# Altium schematic sheet 07)RADEX.SchDoc(07)RADEX)
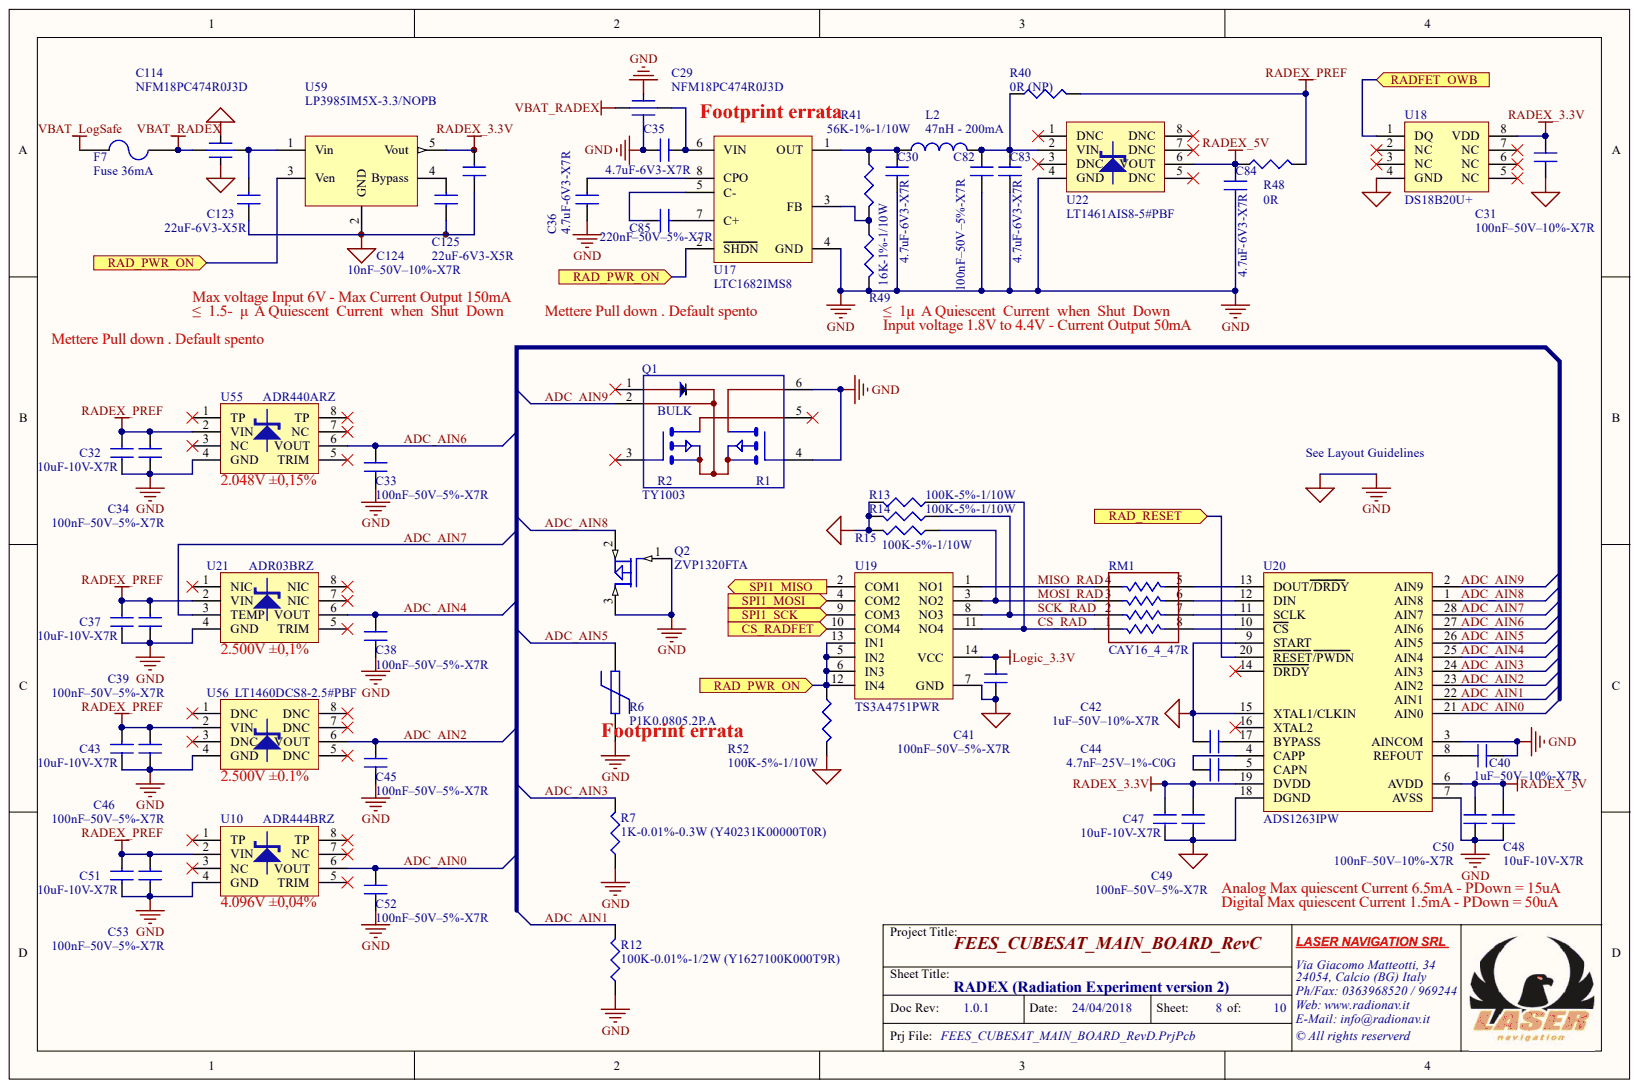
[diagram p0: 07)RADEX.SchDoc]

## Parts
C29  NFM18PC474R0J3D  [0603_EMI_NFM]
C30  4.7uF-6V3-X7R  [0603_CAP]
C31  100nF–50V–10%-X7R  [0603_CAP]
C32  10uF-10V-X7R  [0805_CAP]
C33  100nF–50V–5%-X7R  [0603_CAP]
C34  100nF–50V–5%-X7R  [0603_CAP]
C35  4.7uF-6V3-X7R  [0603_CAP]
C36  4.7uF-6V3-X7R  [0603_CAP]
C37  10uF-10V-X7R  [0805_CAP]
C38  100nF–50V–5%-X7R  [0603_CAP]
C39  100nF–50V–5%-X7R  [0603_CAP]
C40  1uF–50V–10%-X7R  [0805_CAP]
C41  100nF–50V–5%-X7R  [0603_CAP]
C42  1uF–50V–10%-X7R  [0805_CAP]
C43  10uF-10V-X7R  [0805_CAP]
C44  4.7nF–25V–1%-C0G  [0603_CAP]
C45  100nF–50V–5%-X7R  [0603_CAP]
C46  100nF–50V–5%-X7R  [0603_CAP]
C47  10uF-10V-X7R  [0805_CAP]
C48  10uF-10V-X7R  [0805_CAP]
C49  100nF–50V–5%-X7R  [0603_CAP]
C50  100nF–50V–10%-X7R  [0603_CAP]
C51  10uF-10V-X7R  [0805_CAP]
C52  100nF–50V–5%-X7R  [0603_CAP]
C53  100nF–50V–5%-X7R  [0603_CAP]
C82  100nF–50V–5%-X7R  [0603_CAP]
C83  4.7uF-6V3-X7R  [0603_CAP]
C84  4.7uF-6V3-X7R  [0603_CAP]
C85  220nF–50V–5%-X7R  [0603_CAP]
C114  NFM18PC474R0J3D  [0603_EMI_NFM]
C123  22uF-6V3-X5R  [0805_CAP]
C124  10nF–50V–10%-X7R  [0603_CAP]
C125  22uF-6V3-X5R  [0805_CAP]
F7  Fuse 36mA  [0603_FUSE]
L2  47nH - 200mA  [0603_IND]
Q1  TY1003  [SOT23-6]
Q2  ZVP1320FTA  [SOT23]
R6  P1K0.0805.2P.A  [1206_RES]
R7  1K-0.01%-0.3W (Y40231K00000T0R)  [1206_RES]
R12  100K-0.01%-1/2W (Y1627100K000T9R)  [2010_RES]
R13  100K-5%-1/10W  [0603_RES]
R14  100K-5%-1/10W  [0603_RES]
R15  100K-5%-1/10W  [0603_RES]
R40  0R (NP)  [0603_RES]
R41  56K-1%-1/10W  [0603_RES]
R48  0R  [0603_RES]
R49  16K-1%-1/10W  [0603_RES]
R52  100K-5%-1/10W  [0603_RES]
RM1  CAY16_4_47R  [RETE_CAY16_4]
U10  ADR444BRZ  [SO8]
U17  LTC1682IMS8  [TSSOP8]
U18  DS18B20U+  [MSOP8]
U19  TS3A4751PWR  [TSSOP14]
U20  ADS1263IPW  [TSSOP28]
U21  ADR03BRZ  [SO8]
U22  LT1461AIS8-5#PBF  [SO8]
U55  ADR440ARZ  [SO8]
U56  LT1460DCS8-2.5#PBF  [SO8]
U59  LP3985IM5X-3.3/NOPB  [SOT23-5]

## Ports
CS_RADFET, RAD_PWR_ON, RAD_RESET, RADFET_OWB, SPI1_MISO, SPI1_MOSI, SPI1_SCK

## Nets
GND: C29.3 C29.4 C30.2 C31.2 C32.1 C33.2 C34.2 C35.1 C36.2 C37.1 C38.2 C39.2 C40.2 C41.2 C42.2 C43.1 C45.2 C46.2 C47.1 C48.1 C49.2 C50.2 C51.1 C52.2 C53.2 C82.2 C83.2 C84.2 C114.3 C114.4 C123.2 C124.2 C125.2 Q1.4 Q1.6 Q2.1 Q2.3 R6.1 R7.1 R12.1 R13.2 R14.2 R15.2 R49.2 R52.2 U10.4(GND) U17.4(GND) U18.4(GND) U19.7(GND) U20.3(AINCOM) U20.7(AVSS) U20.9(START) U20.15(XTAL1/CLKIN) U20.18(DGND) U21.4(GND) U22.4(GND) U55.4(GND) U56.4(GND) U59.2(GND)
LOGIC_3.3V: C41.1 U19.14(VCC)
RADEX_3.3V: C31.1 C47.2 C49.1 C125.1 U18.8(VDD) U20.19(DVDD) U59.5(Vout)
RADEX_5V: C48.2 C50.1 C84.1 R48.1 U20.6(AVDD) U22.6(VOUT)
RADEX_PREF: C32.2 C34.1 C37.2 C39.1 C43.2 C46.1 C51.2 C53.1 R40.2 R48.2 U10.2(VIN) U21.2(VIN) U55.2(VIN) U56.2(VIN)
VBAT_LOGSAFE: F7.1
VBAT_RADEX: C29.1 C114.1 F7.2
ADC_AIN0: C52.1 U10.6(VOUT) U20.21(AIN0)
ADC_AIN1: R12.2 U20.22(AIN1)
ADC_AIN2: C45.1 U20.23(AIN2) U56.6(VOUT)
ADC_AIN3: R7.2 U20.24(AIN3)
ADC_AIN4: C38.1 U20.25(AIN4) U21.6(VOUT)
ADC_AIN5: R6.2 U20.26(AIN5)
ADC_AIN6: C33.1 U20.27(AIN6) U55.6(VOUT)
ADC_AIN7: U20.28(AIN7) U21.3(TEMP)
ADC_AIN8: Q2.2 U20.1(AIN8)
ADC_AIN9: Q1.2 U20.2(AIN9)
CS_RAD: R13.1 RM1.1 U19.11(NO4)
CS_RADFET: U19.10(COM4) ; port CS_RADFET
MISO_RAD: RM1.4 U19.1(NO1)
MOSI_RAD: R15.1 RM1.3 U19.3(NO2)
RAD_PWR_ON: R52.1 U17.2(SHDN) U19.5(IN2) U19.6(IN3) U19.12(IN4) U19.13(IN1) U59.3(Ven) ; port RAD_PWR_ON
RAD_RESET: U20.20(RESET/PWDN) ; port RAD_RESET
RADFET_OWB: U18.1(DQ) ; port RADFET_OWB
SCK_RAD: R14.1 RM1.2 U19.8(NO3)
SPI1_MISO: U19.2(COM1) ; port SPI1_MISO
SPI1_MOSI: U19.4(COM2) ; port SPI1_MOSI
SPI1_SCK: U19.9(COM3) ; port SPI1_SCK
NetC29_2: C29.2 C35.2 U17.6(VIN)
NetC30_1: C30.1 L2.1 R41.1 U17.1(OUT)
NetC36_1: C36.1 U17.8(CPO)
NetC40_1: C40.1 U20.8(REFOUT)
NetC42_1: C42.1 U20.17(BYPASS)
NetC44_1: C44.1 U20.5(CAPN)
NetC44_2: C44.2 U20.4(CAPP)
NetC82_1: C82.1 C83.1 L2.2 R40.1 U22.2(VIN)
NetC85_1: C85.1 U17.5(C-)
NetC85_2: C85.2 U17.7(C+)
NetC114_2: C114.2 C123.1 U59.1(Vin)
NetC124_1: C124.1 U59.4(Bypass)
NetR41_2: R41.2 R49.1 U17.3(FB)
NetRM1_5: RM1.5 U20.13(DOUT/DRDY)
NetRM1_6: RM1.6 U20.12(DIN)
NetRM1_7: RM1.7 U20.11(SCLK)
NetRM1_8: RM1.8 U20.10(CS)
N00328:

Not connected: Q1 1 3 5; U10 1(TP) 3(NC) 5(TRIM) 7(NC) 8(TP); U18 2(NC) 3(NC) 5(NC) 6(NC) 7(NC); U20 14(DRDY) 16(XTAL2); U21 1(NIC) 5(TRIM) 7(NIC) 8(NIC); U22 1(DNC) 3(DNC) 5(DNC) 7(DNC) 8(DNC); U55 1(TP) 3(NC) 5(TRIM) 7(NC) 8(TP); U56 1(DNC) 3(DNC) 5(DNC) 7(DNC) 8(DNC)

## Library data of the parts
C29 C114 = CAP_EMI: Capacitor; 2.Manufacturer: Murata; 3.Description: CAP FEEDTHRU 0.47UF 6.3V 0603; 5.Mounting: Y; 1.Part Number: NFM18PC474R0J3D; 4.Supplier: Digikey 490-6973-1-ND; Component Kind: Standard; Library Name: User Sch Library.SchLib
C30 C35 C36 C83 C84 = CAP: Non polarized capacitor; 1.Part Number: CL10B475KQ8NQNC; 2.Manufacturer: Samsung; 3.Description: CAP CER 4.7UF 6.3V X7R 0603; 5.Mounting: Y; 4.Supplier: Digikey 1276-2087-1-ND; Component Kind: Standard; Library Name: User Sch Library.SchLib
C31 C50 C124 = CAP: Non polarized capacitor; Component Kind: Standard; Library Name: User Sch Library.SchLib
C32 C37 C43 C47 C48 C51 = CAP: Non polarized capacitor; 1.Part Number: CC0805KKX7R6BB106; 2.Manufacturer: Yageo; 3.Description: CAP CER 10UF 10V X7R 0805; 5.Mounting: Y; 4.Supplier: Digikey 311-1879-1-ND; Component Kind: Standard; Library Name: User Sch Library.SchLib
C33 C34 C38 C39 C41 C45 C46 C49 C52 C53 C82 = CAP: Non polarized capacitor; 1.Part Number: CC0603JRX7R9BB104; 2.Manufacturer: Yageo; 3.Description: CAP CER 0.1UF 50V X7R 0603; 5.Mounting: Y; 4.Supplier: Digikey 311-1779-1-ND; Component Kind: Standard; Library Name: User Sch Library.SchLib
C40 C42 = CAP: Non polarized capacitor; 1.Part Number: 08055C105K4Z2A; 2.Manufacturer: AVX Corporation; 3.Description: CAP CER 1UF 50V X7R 0805; 5.Mounting: Y; 4.Supplier: Digikey 478-9557-1-ND; Component Kind: Standard; Library Name: User Sch Library.SchLib
C44 = CAP: Non polarized capacitor; 1.Part Number: C0603C472F3GACTU; 2.Manufacturer: KEMET; 3.Description: CAP CER 4700PF 25V C0G/NP0 0603; 5.Mounting: Y; 4.Supplier: Digikey 399-9087-1-ND; Component Kind: Standard; Library Name: User Sch Library.SchLib
C85 = CAP: Non polarized capacitor; 1.Part Number: CC0805KRX7R7BB224; 2.Manufacturer: Yageo; 3.Description: CAP CER 0.22UF 16V X7R 0805; 5.Mounting: Y; 4.Supplier: Digikey 311-3390-1-ND; Component Kind: Standard; Library Name: User Sch Library.SchLib
C123 C125 = CAP: Non polarized capacitor; 1.Part Number: CC0805MKX5R5BB226; 2.Manufacturer: Yageo; 3.Description: CAP CER 22UF 6.3V X5R 0805; 5.Mounting: Y; 4.Supplier: Digikey 	311-1464-1-ND; Component Kind: Standard; Library Name: User Sch Library.SchLib
F7 = FUSE2: Fuse; 1.Part Number: PRG18BB330MS1RB; 2.Manufacturer: Murata Electronics North America; 3.Description: THERMISTOR PTC 33 OHM SMD; 5.Mounting: Y; 4.Supplier: Digikey 490-12121-1-ND; Component Kind: Standard; Library Name: User Sch Library.SchLib
L2 = INDUCTOR: 1.Part Number: MLF1608D47NMTA00; 2.Manufacturer: TDK Corporation; 3.Description: FIXED IND 47NH 200MA 200 MOHM; 5.Mounting: Y; 4.Supplier: Digikey 445-1000-1-ND; Component Kind: Standard; Description: Inductor; Library Name: User Sch Library.SchLib
Q1 = TY1003: RADFET; 2.Manufacturer: tyndallwork; 3.Description: RADFET,discrete p-channel MOSFET for radiation sensitivity; 5.Mounting: Y; 1.Part Number: TY1003; 4.Supplier: tyndallwork.com; Component Kind: Standard; Library Name: User Sch Library.SchLib
Q2 = MOSFET P_1: 2.Manufacturer: Diodes Incorporated; 3.Description: MOSFET P-CH 200V 0.035A SOT23-3; 5.Mounting: Y; 1.Part Number: ZVP1320FTA; 4.Supplier: Digikey ZVP1320FCT-ND; Component Kind: Standard; Library Name: User Sch Library.SchLib
R6 = RES_TERMO: 2.Manufacturer: IST; 3.Description: RTD Sensor, Class A, 0805, -50 °C, +150 °C, 1 kohm; 5.Mounting: Y; 1.Part Number: P1K0.0805.2P.A; 4.Supplier: Farnell 1266951; Component Kind: Standard; Library Name: User Sch Library.SchLib
R7 = R: 2.Manufacturer: Vishay; 3.Description: RES SMD 1K OHM 0.01% 0.3W 1206; 5.Mounting: Y; 1.Part Number: Y40231K00000T0R; 4.Supplier: Digikey Y4023-1.0KBCT-ND; Component Kind: Standard; Library Name: User Sch Library.SchLib
R12 = R: 2.Manufacturer: Vishay; 3.Description: RES SMD 100K OHM 0.01% 1/2W 2010; 5.Mounting: Y; 1.Part Number: Y1627100K000T9R; 4.Supplier: Digikey Y1627-100KCT-ND; Component Kind: Standard; Library Name: User Sch Library.SchLib
R13-R15 R52 = R: Component Kind: Standard; Library Name: User Sch Library.SchLib
R40 = R: 5.Mounting: not mounted; Component Kind: Standard; Library Name: User Sch Library.SchLib
R41 = R: 1.Part Number: RC0603FR-0756KL; 2.Manufacturer: Yageo; 3.Description: RES SMD 56K OHM 1% 1/10W 0603; 5.Mounting: Y; 4.Supplier: Digikey 311-56KHRCT-ND; Component Kind: Standard; Library Name: User Sch Library.SchLib
R48 = R: 5.Mounting: Y; Component Kind: Standard; Library Name: User Sch Library.SchLib
R49 = R: 1.Part Number: RC0603FR-0716KL; 2.Manufacturer: Yageo; 3.Description: RES SMD 16K OHM 1% 1/10W 0603; 5.Mounting: Y; 4.Supplier: Digikey 311-16.0KHRCT-ND; Component Kind: Standard; Library Name: User Sch Library.SchLib
RM1 = RES_CAY16_4_TOT: 1.Part Number: CAY16-470J4LF; 2.Manufacturer: Bourns Inc.; 3.Description: RES ARRAY 47 OHM 4 RES 1206; 5.Mounting: Y; 4.Supplier: RS 522-5715 // Digikey CAY16-470J4LFCT-ND; Component Kind: Standard; Library Name: User Sch Library.SchLib
U10 = ADR44XX: Voltage Reference; 2.Manufacturer: Analog Devices Inc.; 3.Description: IC VREF SERIES 4.096V 8SOIC; 5.Mounting: Y; 1.Part Number: ADR444BRZ; 4.Supplier: Digikey ADR444BRZ-ND; Component Kind: Standard; Library Name: User Sch Library.SchLib
U17 = LTC1682IMS8: IC CONV DC/DC; 1.Part Number: LTC1682IMS8#PBF; 2.Manufacturer: Linear Technology; 3.Description: IC REG SWTCHD CAP ADJ 50MA 8MSOP; 5.Mounting: Y; 4.Supplier: Digikey LTC1682IMS8#PBF-ND; Component Kind: Standard; Library Name: User Sch Library.SchLib
U18 = DS18B20U: SENSOR TEMPERATURE 1-WIRE 8UMAX; 2.Manufacturer: Maxim Integrated; 5.Mounting: Y; 1.Part Number: DS18B20U+; 3.Description: SENSOR TEMPERATURE 1-WIRE 8UMAX; 4.Supplier: Digikey DS18B20U+-ND; Component Kind: Standard; Library Name: User Sch Library.SchLib
U19 = TS3A4751PWR: NOR gate; 2.Manufacturer: Texas Instruments; 3.Description: IC SWITCH QUAD SPST 14TSSOP; 5.Mounting: Y; 1.Part Number: TS3A4751PWR; 4.Supplier: Digikey 296-20700-1-ND; Component Kind: Standard; Library Name: User Sch Library.SchLib
U20 = ADS1263IPW: 8ch ADC National; 2.Manufacturer: Texas Instruments; 3.Description: IC ADC 32BIT 38KSPS 28TSSOP; 5.Mounting: Y; 1.Part Number: ADS1263IPW; 4.Supplier: Digikey 296-42313-5-ND; Component Kind: Standard; Library Name: User Sch Library.SchLib
U21 = ADR0X: Voltage Reference; 2.Manufacturer: Analog Devices Inc.; 3.Description: IC VREF SERIES 2.5V 8SOIC; 5.Mounting: Y; 1.Part Number: ADR03BRZ; 4.Supplier: Digikey ADR03BRZ-ND; Component Kind: Standard; Library Name: User Sch Library.SchLib
U22 = LT1460DCS8: Voltage Reference; 2.Manufacturer: Linear Technology; 3.Description: IC VREF SERIES 5V 8SOIC; 5.Mounting: Y; 1.Part Number: LT1461AIS8-5#PBF; 4.Supplier: Digikey LT1461AIS8-5#PBF-ND; Component Kind: Standard; Library Name: User Sch Library.SchLib
U55 = ADR44XX: Voltage Reference; 2.Manufacturer: Analog Device; 3.Description: IC VREF SERIES 2.048V 8SOIC; 5.Mounting: Y; 1.Part Number: ADR440ARZ; 4.Supplier: Digikey ADR440ARZ-ND; Component Kind: Standard; Library Name: User Sch Library.SchLib
U56 = LT1460DCS8: Voltage Reference; 2.Manufacturer: Linear Technology; 3.Description: IC VREF SERIES 2.5V 8SOIC; 5.Mounting: Y; 1.Part Number: LT1460DCS8-2.5#PBF; 4.Supplier: Digikey LT1460DCS8-2.5#PBF-ND; Component Kind: Standard; Library Name: User Sch Library.SchLib
U59 = LP3985: Voltage regulator low dropout; 2.Manufacturer: Texas Instruments; 3.Description: Linear Voltage Regulator IC Positive Fixed 1 Output 3.3V 150mA SOT-23-5; 5.Mounting: Y; 1.Part Number: LP3985IM5X-3.3/NOPB; 4.Supplier: Digikey LP3985IM5X-3.3/NOPBCT-ND; Component Kind: Standard; Library Name: User Sch Library.SchLib
